# Altium schematic sheet Conn.SchDoc
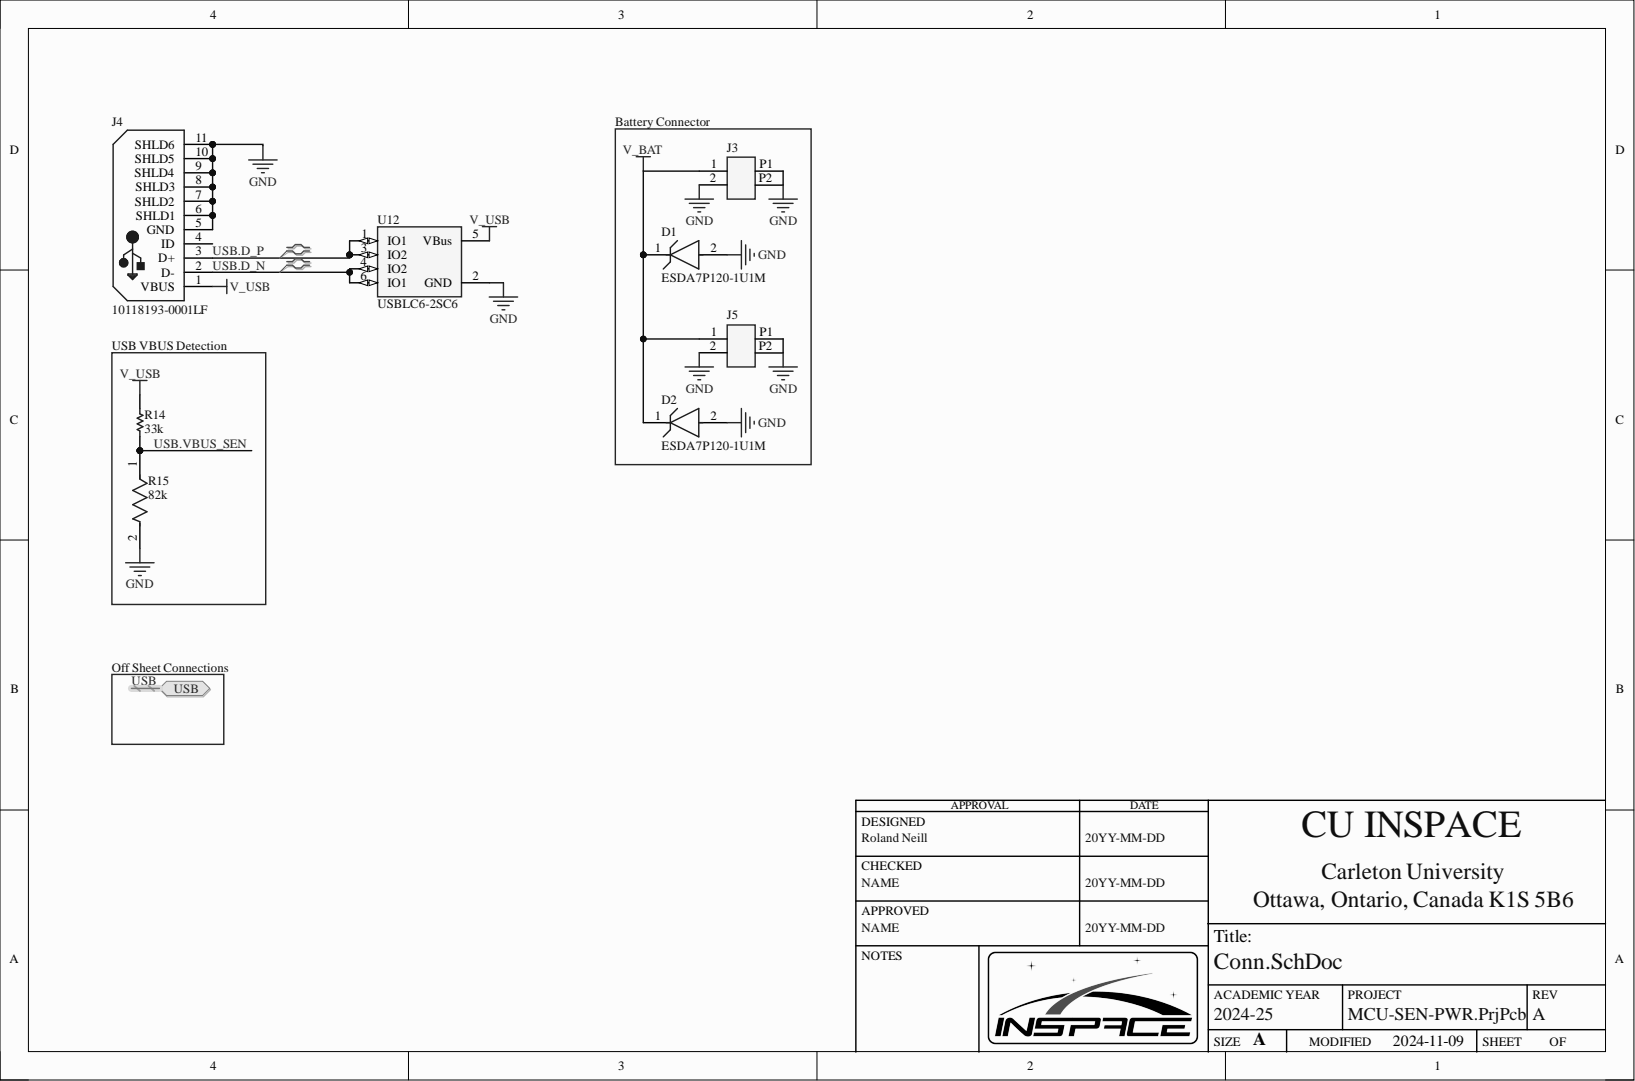
[diagram p0: Conn.SchDoc]

## Parts
D1  ESDA7P120-1U1M  [FP-QFN1610-MFG]
D2  ESDA7P120-1U1M  [FP-QFN1610-MFG]
J3  S2B-PH-SM4-TB  [JST_S2B-PH-SM4-TB]  (value not drawn)
J4  10118193-0001LF  [FCI-10118193-0001LF-5_V]
J5  S2B-PH-SM4-TB  [JST_S2B-PH-SM4-TB]  (value not drawn)
R14  value 33k  [RESC0805(2012)_M]  (hidden comment: CR0805-JW-333ELF)
R15  value 82k  [FP-RT0805-MFG]  (hidden comment: RT0805BRE0782KL)
U12  USBLC6-2SC6  [STM-SOT23-6L_V]

## Ports
USB, USB.D_N, USB.D_P, USB.VBUS_SEN

## Nets
GND: D1.2 D2.2 J3.2 J4.5(GND) J4.6(SHLD1) J4.7(SHLD2) J4.8(SHLD3) J4.9(SHLD4) J4.10(SHLD5) J4.11(SHLD6) J5.2 R15.2 U12.2(GND)
V_BAT: D1.1 D2.1 J3.1 J5.1
V_USB: J4.1(VBUS) R14.2 U12.5(VBus)
USB.D_N: J4.2(D-) U12.4(IO2) U12.6(IO1) ; port USB
USB.D_P: J4.3(D+) U12.1(IO1) U12.3(IO2) ; port USB
USB.VBUS_SEN: R14.1 R15.1 ; port USB

Not connected: J3 P1 P2; J4 4(ID); J5 P1 P2

## Library data of the parts
D1 D2 = CMP-004-00039-1: Trans Voltage Suppressor Diode, 1400W, 5.5V V(RWM), Unidirectional, 1 Element, Silicon; Component Kind: Standard; Diode Type: TRANS VOLTAGE SUPPRESSOR; Reach SVHC Compliant: Yes; RoHS Compliant: Yes; Library Name: Americas- CU InSpace-  Carleton University
J3 J5 = CMP-042-000003-3: CONN HEADER PH SIDE 2POS 2MM SMD; MF: {'manufacturer': u'JST Sales'}; Availability: Unavailable; Component Kind: Standard; Component Type: Standard; Design Item ID: S2B-PH-SM4-TB; MP: S2B-PH-SM4-TB; Package: None; Pin Count: 2; Price: None; Source: S2B-PH-SM4-TB.SchLib; Library Name: Americas- CU InSpace-  Carleton University
J4 = CMP-002-00083-1: FCI Right Angle Surface Mount Mount Female USB Connector; 100 V ac; 1.8A; Connector Type: USB,Receptacle; Insulation Resistance: 1GR; Max Voltage Rating (AC): 100V; Number of Contacts: 5; Number of Ports: 1; Pitch: 0.65mm; Color: Black; Component Kind: Standard; Contact Material: Copper Alloy; Contact Plating: Gold; Current Rating: 1.8A; Depth: 5.67mm; Features: Shielded; Flammability Rating: UL94 V-0; Gender: Female; Housing Material: Polymer; Length: 7.86mm; Max Operating Temperature: 85°C; Min Operating Temperature: -55°C; Mounting Technology: Surface Mount,Horizontal; Orientation: Right Angle; Package Quantity: 1200; Packaging: Tape and Reel; Pins: 5; Radiation Hardening: No; Series: -; Termination: Solder; Voltage Rating: 100V; Library Name: Americas- CU InSpace-  Carleton University
R14 = CMP-009-00191-1: Fixed Resistor, Metal Glaze/thick Film, 0.125W, 33000ohm, 150V, 5% +/-Tol, 200ppm/Cel, Surface Mount, 0805; Package Height: 0.5mm; Package Length: 2mm; Package Width: 1.25mm; Power: 125mW; Rated Temperature: 70°C; Temperature Coefficient: 200ppm/°C; Case/Package: 0805; Component Kind: Standard; Construction: Chip; JESD-609 Code: e3; Max Operating Temperature: 155°C; Min Operating Temperature: -55°C; Mounting Technology: Surface Mount; Package Shape: Rectangular; Packaging: Tape and Reel; Pins: 2; Reach SVHC Compliant: Yes; Resistor Type: FIXED RESISTOR; RoHS Compliant: Yes; Technology: RUTHENIUM OXIDE; Terminal Finish: Nickel; Termination: Wraparound; Tolerance: 5%; Working Voltage: 150V; Library Name: Americas- CU InSpace-  Carleton University
R15 = CMP-009-00192-1: Fixed Resistor, Thin Film, 0.125W, 82000ohm, 150V, 0.1% +/-Tol, 50ppm/Cel, Surface Mount, 0805; Additional Feature: HIGH PRECISION; Package Height: 0.5mm; Package Length: 2mm; Package Width: 1.25mm; Power: 125mW; Rated Temperature: 70°C; Temperature Coefficient: 50ppm/°C; Case/Package: 0805; Component Kind: Standard; Construction: Chip; Max Operating Temperature: 155°C; Min Operating Temperature: -55°C; Mounting Technology: Surface Mount; Packaging: Tape and Reel; Pins: 2; Reach SVHC Compliant: Yes; Resistor Type: FIXED RESISTOR; RoHS Compliant: Yes; Technology: THIN FILM; Termination: Wraparound; Tolerance: 0.1%; Working Voltage: 150V; Library Name: Americas- CU InSpace-  Carleton University
U12 = CMP-004-00038-1: Trans Voltage Suppressor Diode, 5V V(RWM), Unidirectional, 1 Element, Silicon; Additional Feature: HIGH RELIABILITY; Clamping Voltage-Max: 17V; Min Breakdown Voltage: 6V; Number of Elements: 1; Package Body Material: Plastic; Qualification Status: Not Qualified; Reference Standard: IEC-61000-4-2; Component Kind: Standard; Diode Element Material: SILICON; Diode Type: TRANS VOLTAGE SUPPRESSOR; JESD-30 Code: R-PDSO-G6; JESD-609 Code: e3; Max Operating Temperature: 125°C; Min Operating Temperature: -40°C; Moisture Sensitivity Level: 1; Package Shape: Rectangular; Package Style: SMALL OUTLINEMeter; Peak Reflow Temperature (Cel): 260°C; Pins: 6; Polarity: Unidirectional; Reach SVHC Compliant: Yes; Rep Pk Reverse Voltage-Max: 5V; RoHS Compliant: Yes; Surface Mount: Yes; Technology: AVALANCHE; Terminal Finish: Tin; Terminal Form: Gull Wing; Terminal Position: DUAL; Library Name: Americas- CU InSpace-  Carleton University
